# Altium schematic sheet V853_MIPI_CSI&AUDIO&USB.SchDoc(V853_MIPI_CSI&AUDIO&USB)
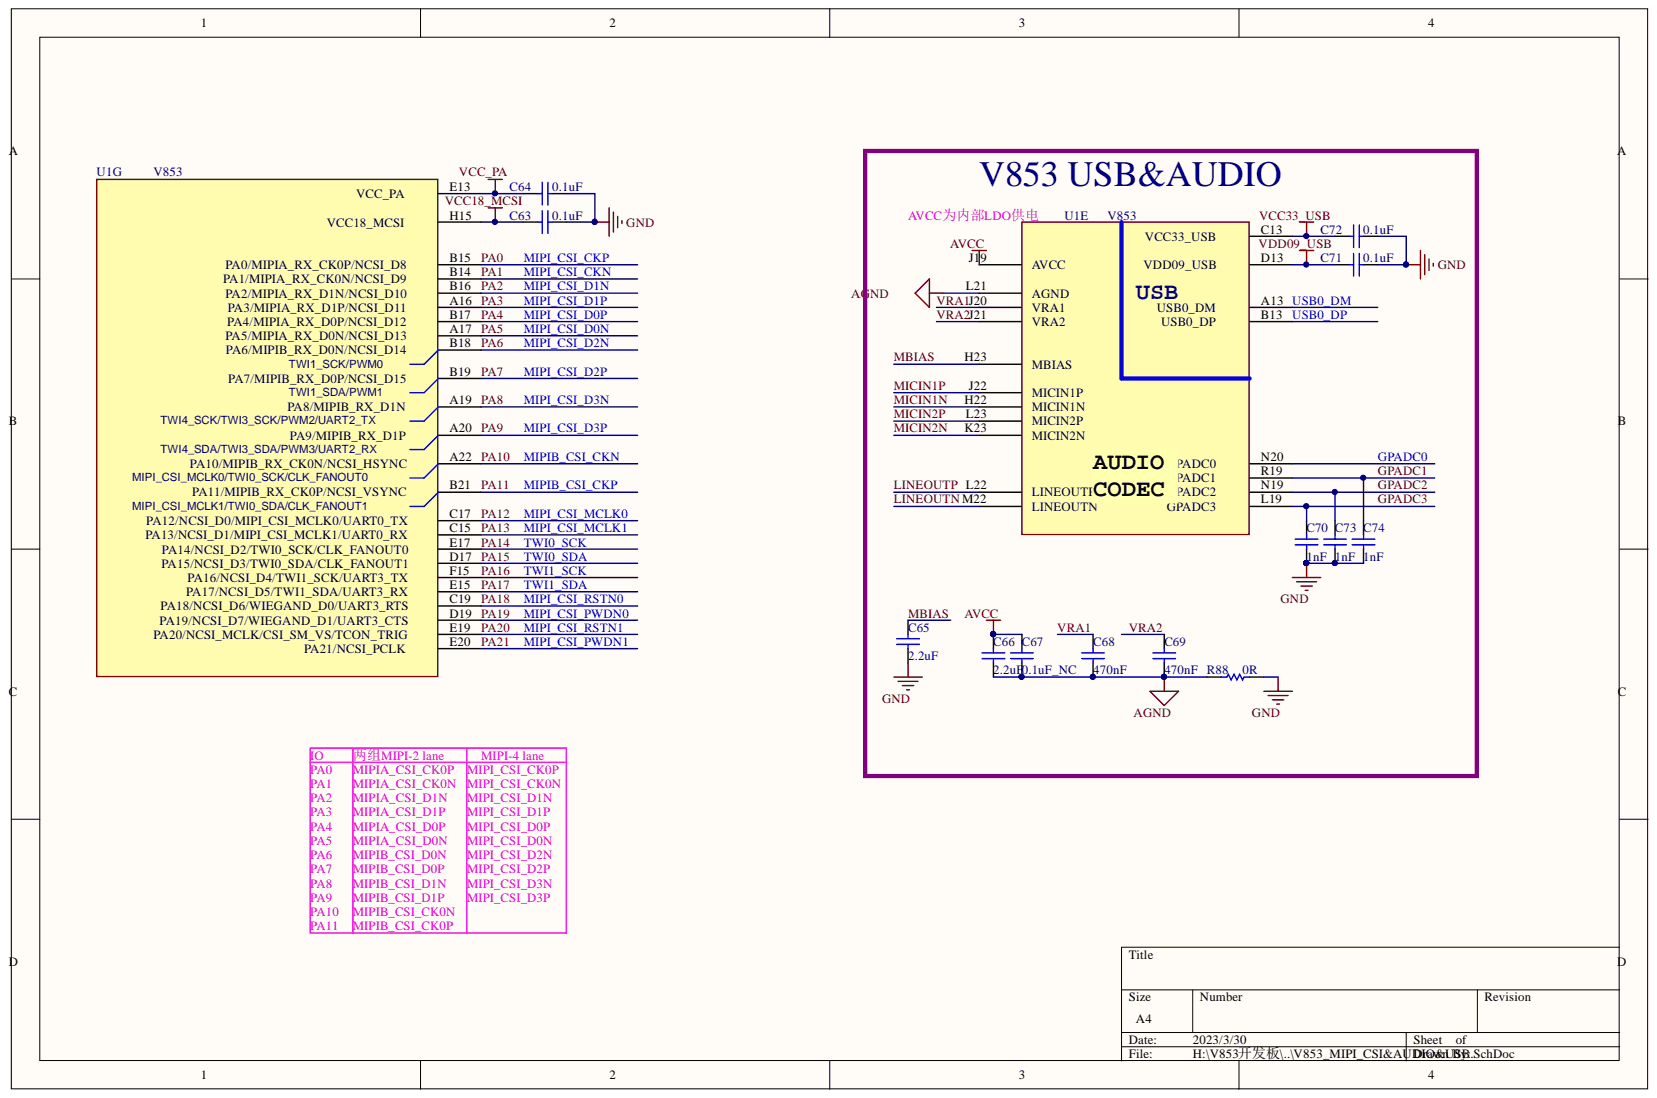
[diagram p0: V853_MIPI_CSI&AUDIO&USB.SchDoc]

## Parts
C63  0.1uF  [C0201]
C64  0.1uF  [C0201]
C65  2.2uF  [0402]
C66  2.2uF  [0402]
C67  0.1uF_NC  [C0201]
C68  470nF  [C0201]
C69  470nF  [C0201]
C70  1nF  [C0201]
C71  0.1uF  [C0201]
C72  0.1uF  [C0201]
C73  1nF  [C0201]
C74  1nF  [C0201]
R88  0R  [0402]
U1  V853  (parts E,G)

## Nets
AGND: C66.2 C67.2 C68.2 C69.2 R88.2 U1.L21(AGND)
AVCC: C66.1 C67.1 U1.J19(AVCC)
GND: C63.1 C64.1 C65.2 C70.1 C71.2 C72.2 C73.1 C74.1 R88.1
VCC18_MCSI: C63.2 U1.H15
VCC33_USB: C72.1 U1.C13
VCC_PA: C64.2 U1.E13
VDD09_USB: C71.1 U1.D13
GPADC0: U1.N20
GPADC1: C74.2 U1.R19
GPADC2: C73.2 U1.N19
GPADC3: C70.2 U1.L19
LINEOUTN: U1.M22(LINEOUTN)
LINEOUTP: U1.L22(LINEOUTP)
MBIAS: C65.1 U1.H23(MBIAS)
MICIN1N: U1.H22(MICIN1N)
MICIN1P: U1.J22(MICIN1P)
MICIN2N: U1.K23(MICIN2N)
MICIN2P: U1.L23(MICIN2P)
MIPI_CSI_CKN: U1.B14
MIPI_CSI_CKP: U1.B15
MIPI_CSI_D0N: U1.A17
MIPI_CSI_D0P: U1.B17
MIPI_CSI_D1N: U1.B16
MIPI_CSI_D1P: U1.A16
MIPI_CSI_D2N: U1.B18
MIPI_CSI_D2P: U1.B19
MIPI_CSI_D3N: U1.A19
MIPI_CSI_D3P: U1.A20
MIPI_CSI_MCLK0: U1.C17
MIPI_CSI_MCLK1: U1.C15
MIPI_CSI_PWDN0: U1.D19
MIPI_CSI_PWDN1: U1.E20
MIPI_CSI_RSTN0: U1.C19
MIPI_CSI_RSTN1: U1.E19
MIPIB_CSI_CKN: U1.A22
MIPIB_CSI_CKP: U1.B21
PA14: U1.E17
PA15: U1.D17
PA16: U1.F15
PA17: U1.E15
USB0_DM: U1.A13
USB0_DP: U1.B13
VRA1: C68.1 U1.J20(VRA1)
VRA2: C69.1 U1.J21(VRA2)

## Library data of the parts
C63-C74 = Cap: Capacitor; PartNumber: CAP100NF; Component Kind: Standard; LatestRevisionDate: 17-Jul-2002; LatestRevisionNote: Re-released for DXP Platform.; Library Name: Miscellaneous Devices.IntLib; PackageReference: 0402; Published: 8-Jun-2000; Signal Integrity: Cap; Simulation: CAP; Publisher: Altium Limited
  C63 C64 C71 C72: Value: 0.1uF
  C65 C66: Value: 2.2uF
  C67: Value: 0.1uF_NC
  C68 C69: Value: 470nF
  C70 C73 C74: Value: 1nF
R88 = Res1: Resistor; PartNumber: RES2.2K; Component Kind: Standard; LatestRevisionDate: 17-Jul-2002; LatestRevisionNote: Re-released for DXP Platform.; Library Name: Miscellaneous Devices.IntLib; PackageReference: 0402; Published: 8-Jun-2000; Signal Integrity: Res; Simulation: RESISTOR; Value: 0R; Publisher: Altium Limited
U1 = V853_0621: PCB Footprint: BGA318P50B1200_1200H113; SPLIT_INST: TRUE; SWAP_INFO: (S1+S2+S3+S4+S5+S6+S7+S8+S9); Component Kind: Standard; Library Name: V853_CORE_20230224.SCHLIB
